# Altium schematic sheet gp2y1010.SchDoc
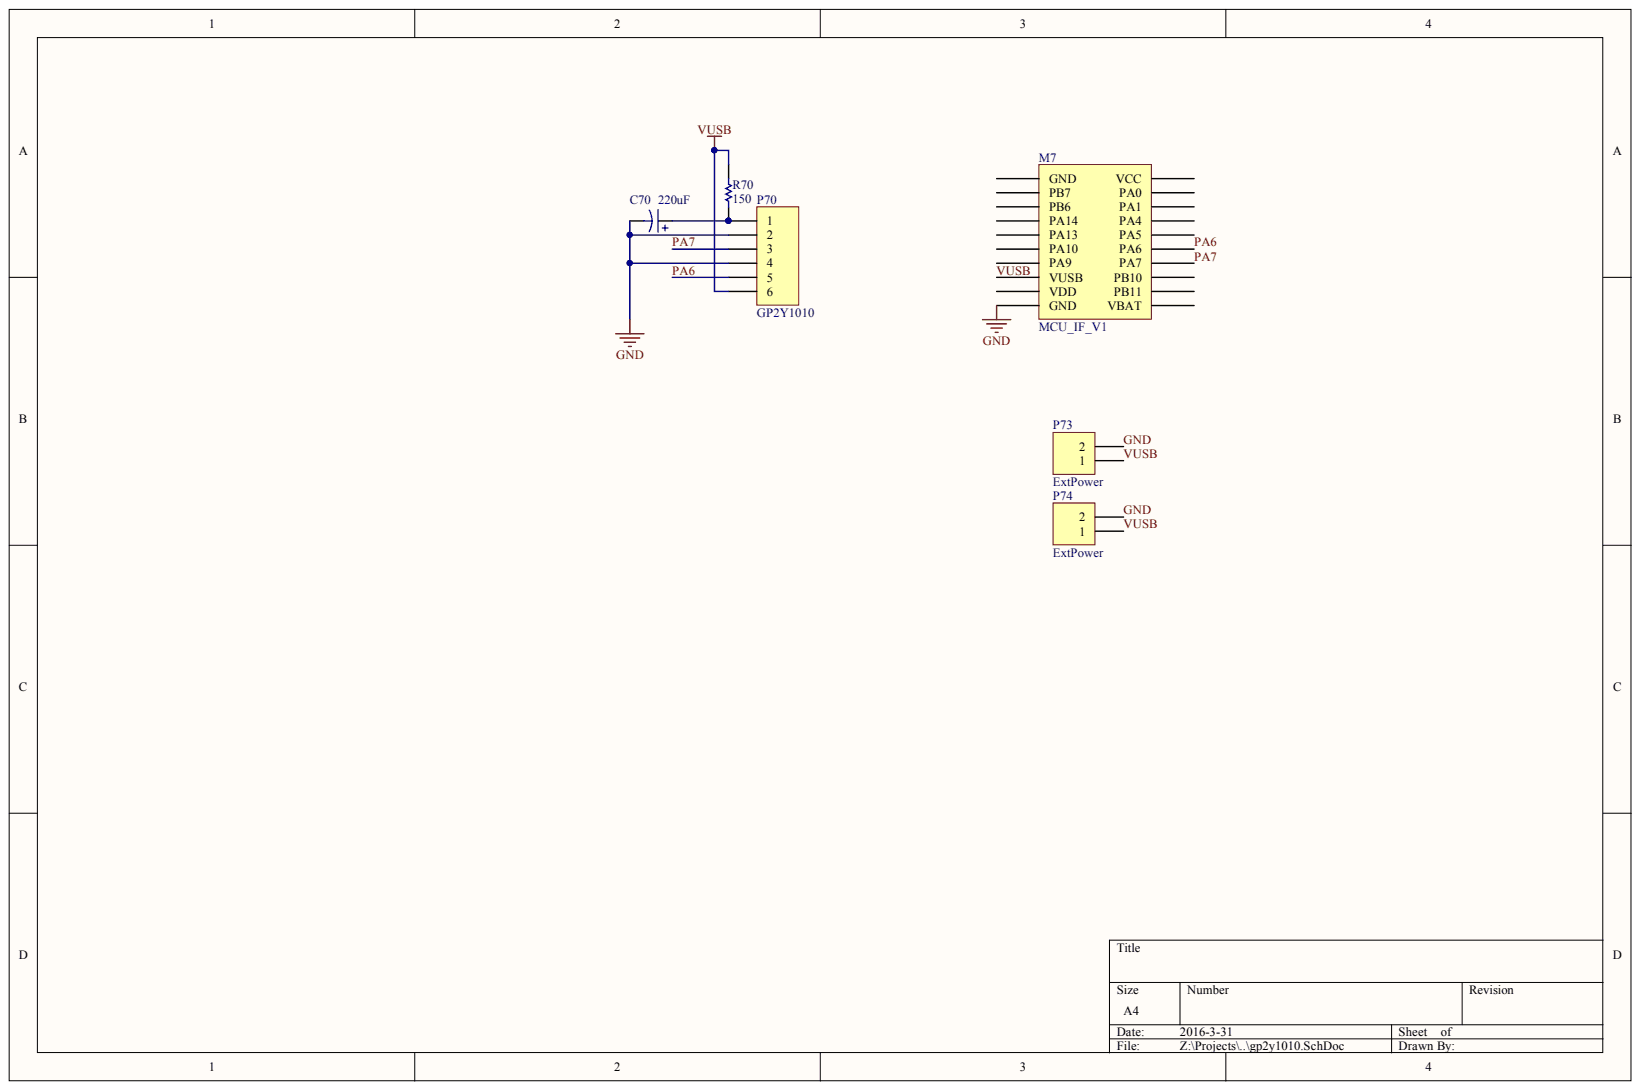
[diagram p0: gp2y1010.SchDoc]

## Parts
C70  value 220uF  (hidden comment: Cap Pol1)
M7  MCU_IF_V1
P70  GP2Y1010
P73  ExtPower
P74  ExtPower
R70  value 150  (hidden comment: Res Semi)

## Nets
GND: C70.2 M7.10(GND) P70.2 P70.4 P73.2 P74.2
PA6: M7.15(PA6) P70.5
PA7: M7.14(PA7) P70.3
VUSB: M7.8(VUSB) P70.6 P73.1 P74.1 R70.2
NetC70_1: C70.1 P70.1 R70.1

Not connected: M7 1(GND) 2(PB7) 3(PB6) 4(PA14) 5(PA13) 6(PA10) 7(PA9) 9(VDD) 11(VBAT) 12(PB11) 13(PB10) 16(PA5) 17(PA4) 18(PA1) 19(PA0) 20(VCC)

## Library data of the parts
C70 M7 P70 P73 P74 R70 = (no symbol name)
  C70: Published: 8-Jun-2000; LatestRevisionDate: 17-Jul-2002; LatestRevisionNote: Re-released for DXP Platform.; PackageReference: RB7.6-15
  P70 P73 P74: LatestRevisionDate: 17-Jul-2002; LatestRevisionNote: Re-released for DXP Platform.
  R70: Published: 8-Jun-2000; LatestRevisionDate: 17-Jul-2002; LatestRevisionNote: Re-released for DXP Platform.; PackageReference: AXIAL-0.5; PackageDescription: Axial Device, Thru-Hole; 2 Leads; 0.5 in Pin Spacing
  C70 P70 P73 P74 R70: Publisher: Altium Limited
